# Altium schematic sheet LEDDriver.SchDoc(LEDDriver)
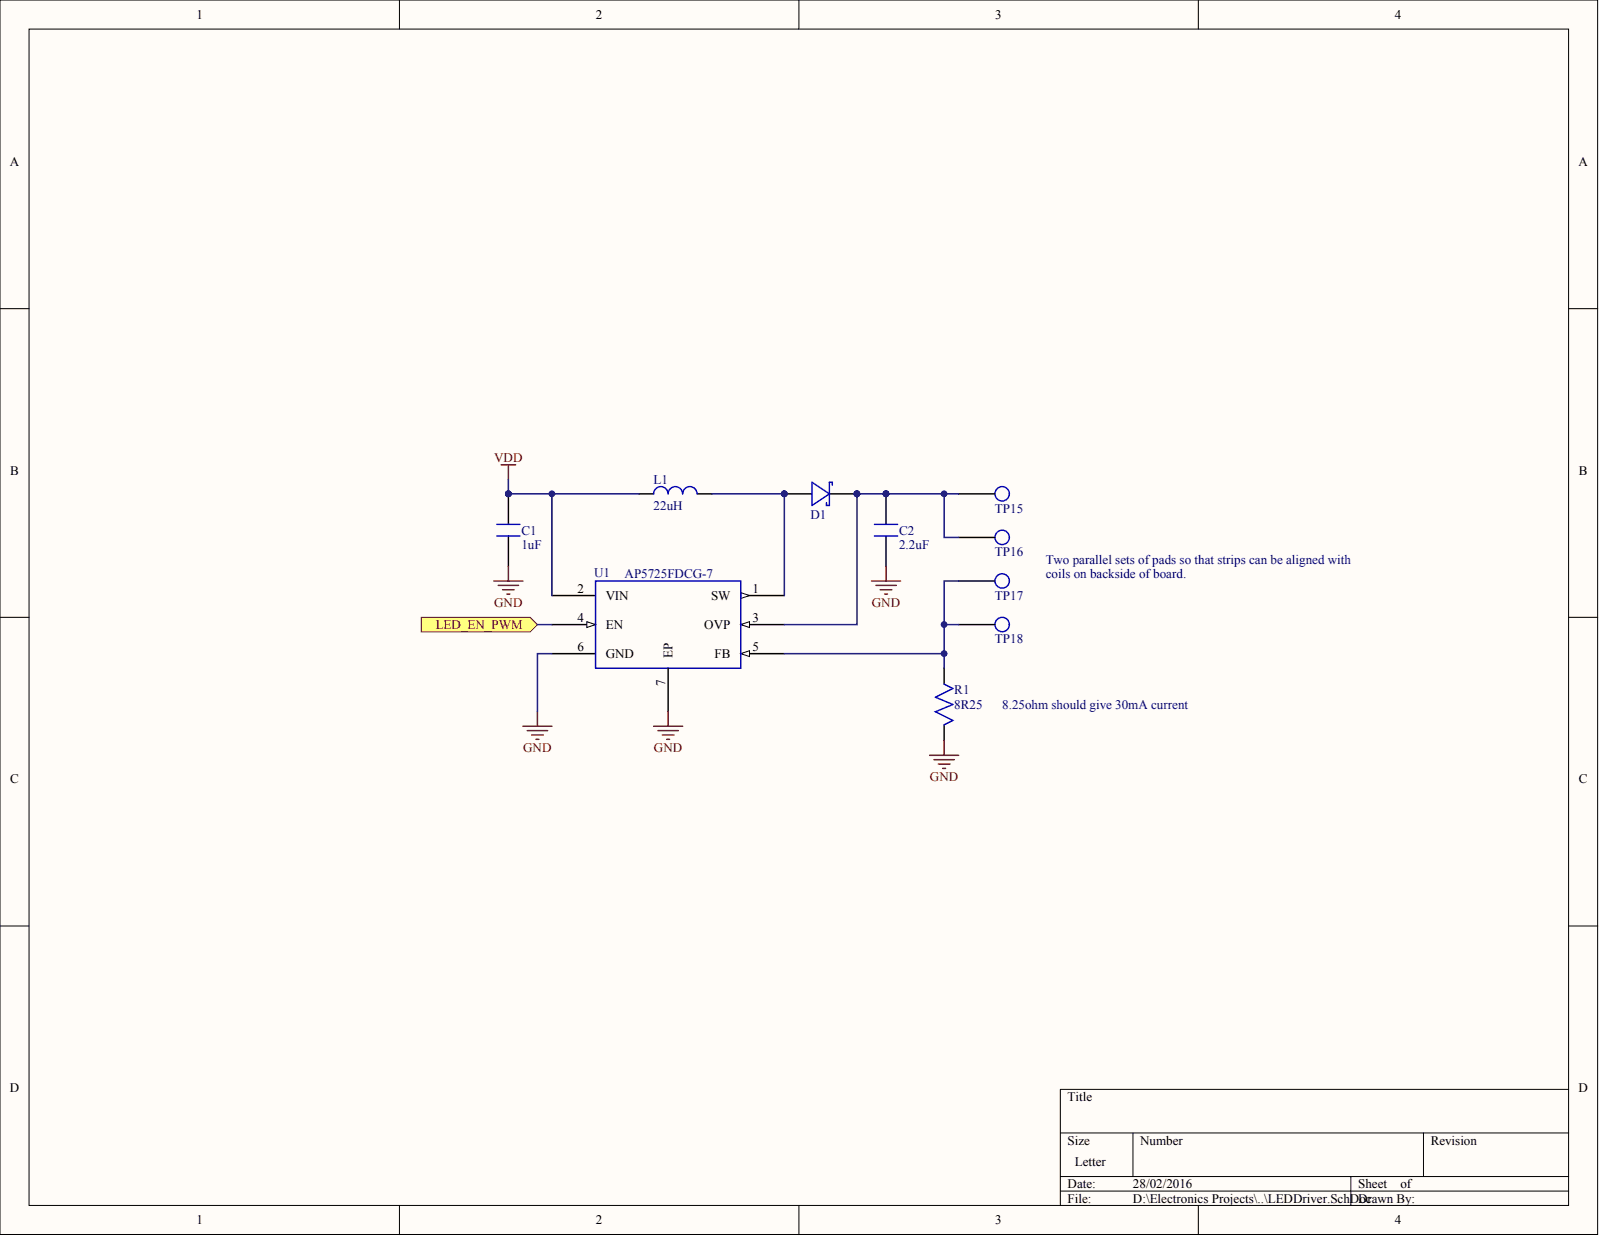
[diagram p0: LEDDriver.SchDoc]

## Parts
C1  Capacitance: 1uF  (hidden comment: CAP_0402_1uF)
C2  Capacitance: 2.2uF  (hidden comment: CAP_0603_2.2uF)
D1  DIODE_NSR0240HT1G  (value not drawn)
L1  Inductance: 22uH  (hidden comment: IND_SRN4018-220M)
R1  Resistance: 8R25  (hidden comment: RES_0402_8R25)
TP15  TP_5018  (value not drawn)
TP16  TP_5018  (value not drawn)
TP17  TP_5018  (value not drawn)
TP18  TP_5018  (value not drawn)
U1  AP5725  Part Number: AP5725FDCG-7

## Ports
LED_EN_PWM

## Nets
GND: C1.1 C2.1 R1.1 U1.6(GND) U1.7(EP)
VDD: C1.2 L1.1 U1.2(VIN)
LED_EN_PWM: U1.4(EN) ; port LED_EN_PWM
NetC2_2: C2.2 D1.1 TP15.1 TP16.1 U1.3(OVP)
NetD1_2: D1.2 L1.2 U1.1(SW)
NetR1_2: R1.2 TP17.1 TP18.1 U1.5(FB)

## Library data of the parts
C1 = (no symbol name): Manufacturer: TDK; Part Number: C1005X5R1A105K050BB; Tolerance: 10%; Voltage: 10V
C2 = (no symbol name): Manufacturer: Murata; Part Number: GRM188R61H225KE11D; Tolerance: 10%; Voltage: 50V
D1 = (no symbol name): Manufacturer: ON Semiconductor; Part Number: NSR0240HT1G; Package: SOD-323
L1 = (no symbol name): Manufacturer: Bourns; DCR: 0.348Ohm; Part Number: SRN4018-220M
R1 = (no symbol name): Manufacturer: Vishay Dale; Part Number: CRCW04028R25FKED; Tolerance: 1%
TP15-TP18 = (no symbol name): Manufacturer: Keystone Electronics; Part Number: 5018
U1 = (no symbol name): Manufacturer: Diodes Incorporated; Package: DFN2020C-6
